# Altium schematic sheet [2]Driver1.SchDoc
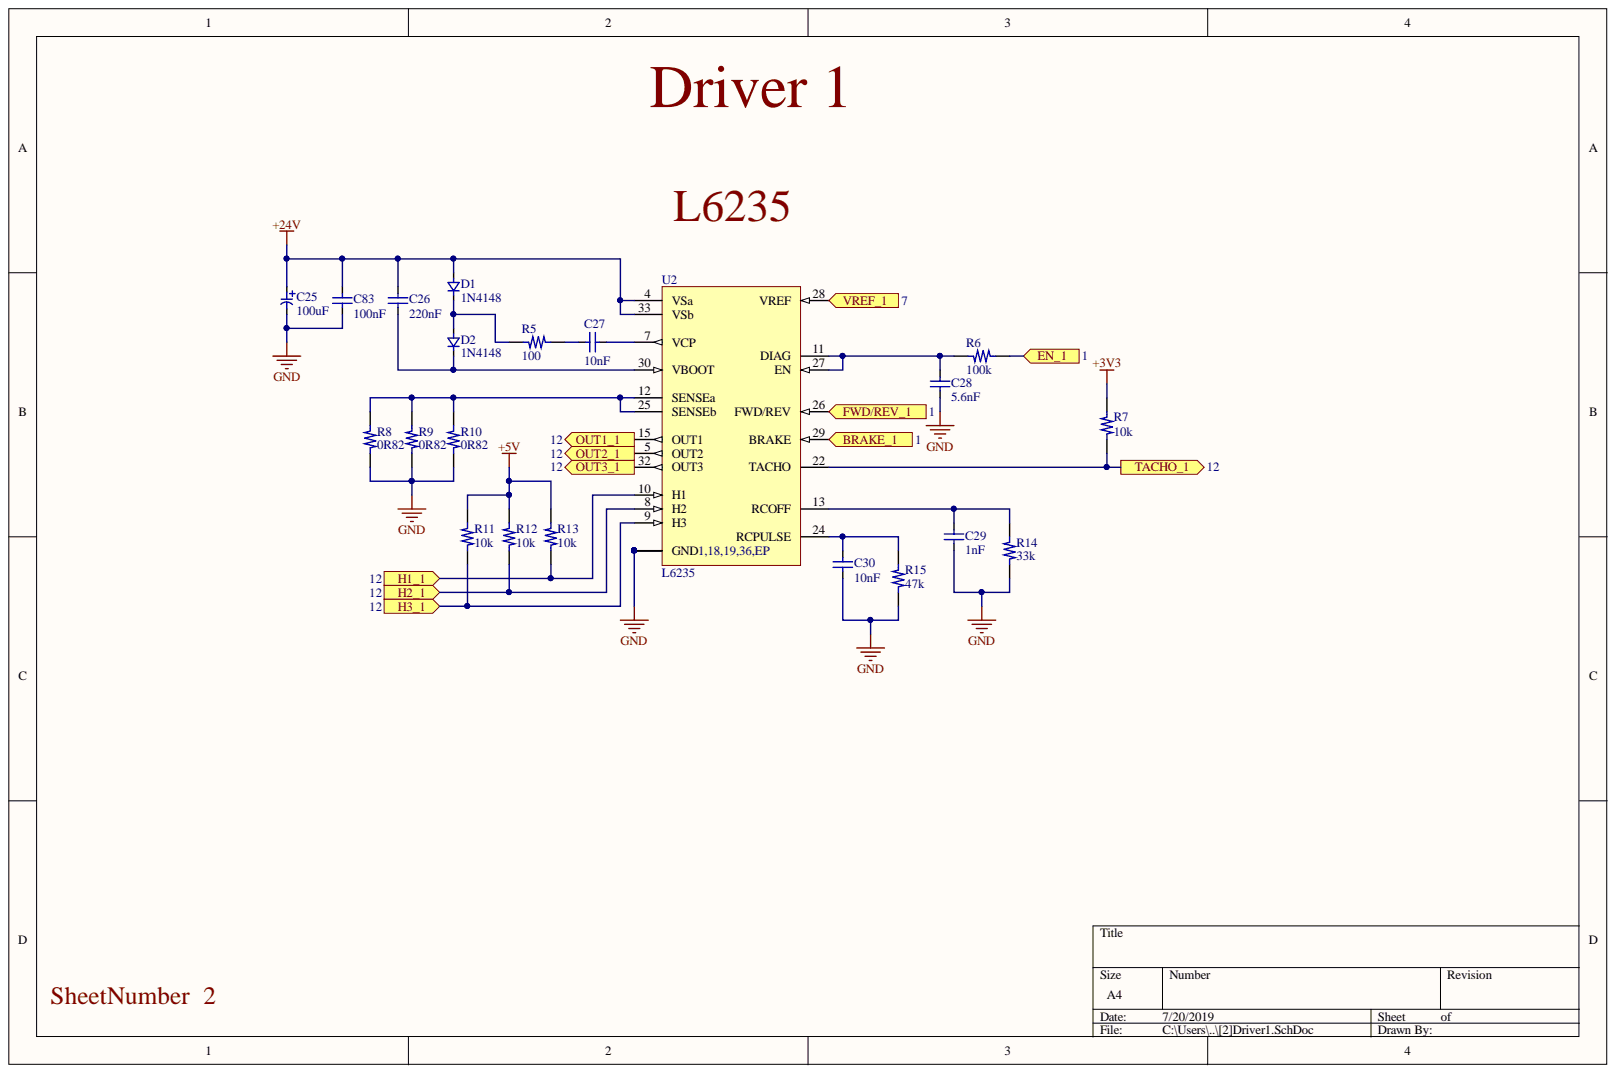
[diagram p0: [2]Driver1.SchDoc]

## Parts
C25  100uF  [Radial SMD 10D]
C26  220nF  [C0805]
C27  10nF  [C0805]
C28  5.6nF  [C0805]
C29  1nF  [C0805]
C30  10nF  [C0805]
C83  100nF  [C0805]
D1  1N4148  [SOD-123]
D2  1N4148  [SOD-123]
R5  100  [R0805]
R6  100k  [R0805]
R7  10k  [R0805]
R8  0R82  [R4122]
R9  0R82  [R4122]
R10  0R82  [R4122]
R11  10k  [R0805]
R12  10k  [R0805]
R13  10k  [R0805]
R14  33k  [R0805]
R15  47k  [R0805]
U2  L6235  [POWERSO36]

## Ports
BRAKE_1, EN_1, FWD/REV_1, H1_1, H2_1, H3_1, OUT1_1, OUT2_1, OUT3_1, TACHO_1, VREF_1

## Nets
+3V3: R7.2
+5V: R11.1 R12.1 R13.1
+24V: C25.1 C26.2 C83.2 D1.1 U2.4(VSa) U2.33(VSb)
GND: C25.2 C28.2 C29.1 C30.1 C83.1 R8.1 R9.1 R10.1 R14.1 R15.1 U2.1 U2.18 U2.19 U2.36 U2.37
BRAKE_1: U2.29(BRAKE) ; port BRAKE_1
EN_1: R6.2 ; port EN_1
FWD/REV_1: U2.26(FWD/REV) ; port FWD/REV_1
H1_1: R13.2 U2.10(H1) ; port H1_1
H2_1: R12.2 U2.8(H2) ; port H2_1
H3_1: R11.2 U2.9(H3) ; port H3_1
OUT1_1: U2.15(OUT1) ; port OUT1_1
OUT2_1: U2.5(OUT2) ; port OUT2_1
OUT3_1: U2.32(OUT3) ; port OUT3_1
TACHO_1: R7.1 U2.22(TACHO) ; port TACHO_1
VREF_1: U2.28(VREF) ; port VREF_1
NetC26_1: C26.1 D2.2 U2.30(VBOOT)
NetC27_1: C27.1 R5.2
NetC27_2: C27.2 U2.7(VCP)
NetC28_1: C28.1 R6.1 U2.11(DIAG) U2.27(EN)
NetC29_2: C29.2 R14.2 U2.13(RCOFF)
NetC30_2: C30.2 R15.2 U2.24(RCPULSE)
NetD1_2: D1.2 D2.1 R5.1
NetR8_2: R8.2 R9.2 R10.2 U2.12(SENSEa) U2.25(SENSEb)

## Library data of the parts
C25 = EEE1JA101P: CAP ALUM 100UF 20% 63V SMD; Mfr 1: Panasonic Electronic Components; Mfr 1 PN: EEE-1JA101P; Component Kind: Standard; Library Name: Components.SchLib
C26 = C0805C224K5RACAUTO: CAP CER 0.22UF 50V X7R 0805; Mfr 1: KEMET; Component Kind: Standard; Library Name: Components.SchLib; Mfr 1 PN: C0805C224K5RACAUTO
C27 C30 = CL21B103KBANFNC: CAP CER 10000PF 50V X7R 0805; Mfr 1: Samsung Electro-Mechanics; Component Kind: Standard; Library Name: Components.SchLib; Mfr 1 PN: CL21B103KBANFNC
C28 = CL21B562KBANNNC: CAP CER 5600PF 50V X7R 0805; Mfr 1: Samsung Electro-Mechanics; Component Kind: Standard; Library Name: Components.SchLib; Mfr 1 PN: CL21B562KBANNNC
C29 = CL21B102KBANFNC: CAP CER 1000PF 50V X7R 0805; Mfr 1: Samsung Electro-Mechanics; Component Kind: Standard; Library Name: Components.SchLib; Mfr 1 PN: CL21B102KBANFNC
C83 = CC0805ZRY5V9BB104: CAP CER 0.1UF 50V Y5V 0805; Mfr 1: Yageo; Component Kind: Standard; Library Name: Components.SchLib; Mfr 1 PN: CC0805ZRY5V9BB104
D1 D2 = 1N4148W-G RHG: DIODE GEN PURP 100V 150MA SOD123; Mfr 1: Taiwan Semiconductor Corporation; Component Kind: Standard; Library Name: Components.SchLib; Mfr 1 PN: 1N4148W-G RHG
R5 = ESR10EZPF1000: RES SMD 100 OHM 1% 0.4W 0805; Mfr 1: Rohm Semiconductor; Component Kind: Standard; Library Name: Components.SchLib; Mfr 1 PN: ESR10EZPF1000
R6 = RC0805FR-07100KL: RES SMD 100K OHM 1% 1/8W 0805; Mfr 1: Yageo; Component Kind: Standard; Library Name: Components.SchLib; Mfr 1 PN: RC0805FR-07100KL
R7 = CRG0805F10K/10: RES SMD 10K OHM 1% 1/8W 0805; Mfr 1: TE Connectivity Passive Product; Component Kind: Standard; Library Name: Components.SchLib; Mfr 1 PN: CRG0805F10K/10
R8-R10 = SMW3R82JT: RES 0.82 OHM 5% 3W 4122; Mfr 1: TE Connectivity Passive Product; Component Kind: Standard; Library Name: Components.SchLib; Mfr 1 PN: SMW3R82JT
R11-R13 = RC0805FR-0710KL: RES SMD 10K OHM 1% 1/8W 0805; Mfr 1: Yageo; Component Kind: Standard; Library Name: Components.SchLib; Mfr 1 PN: RC0805FR-0710KL
R14 = RMCF0805FT33K0: RES 33K OHM 1% 1/8W 0805; Mfr 1: Stackpole Electronics Inc.; Component Kind: Standard; Library Name: Components.SchLib; Mfr 1 PN: RMCF0805FT33K0
R15 = RC0805FR-0747KL: RES SMD 47K OHM 1% 1/8W 0805; Mfr 1: Yageo; Component Kind: Standard; Library Name: Components.SchLib; Mfr 1 PN: RC0805FR-0747KL
U2 = L6235PD: IC MOTOR DRIVER PAR 36POWERSO; Mfr 1: STMicroelectronics; Component Kind: Standard; Library Name: Components.SchLib; Mfr 1 PN: L6235PD
